# Altium schematic sheet Main.SchDoc(Main)
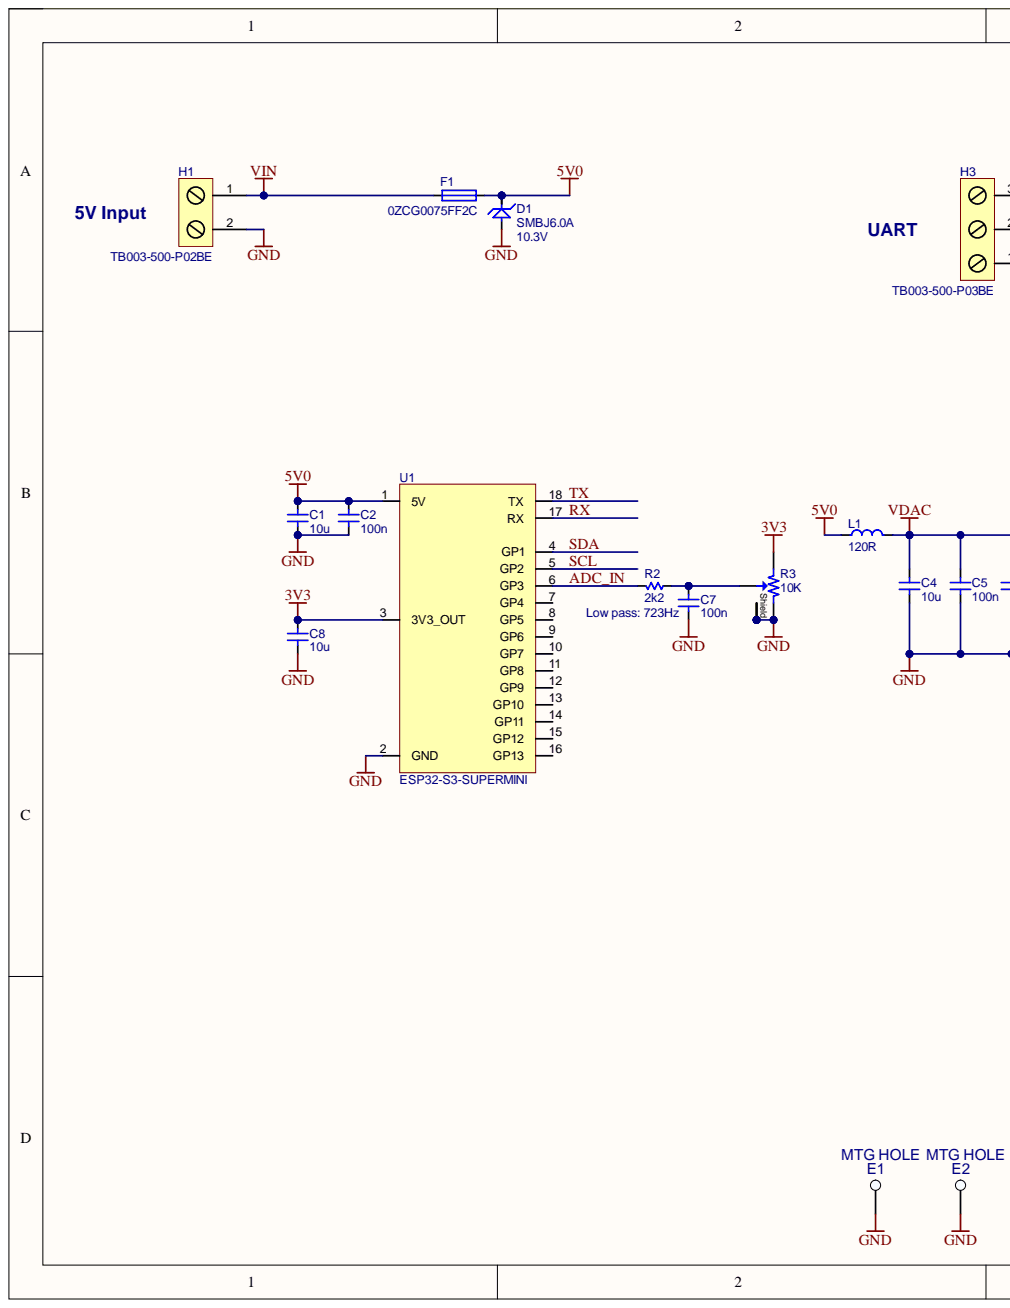
[diagram p0: Main.SchDoc, left]
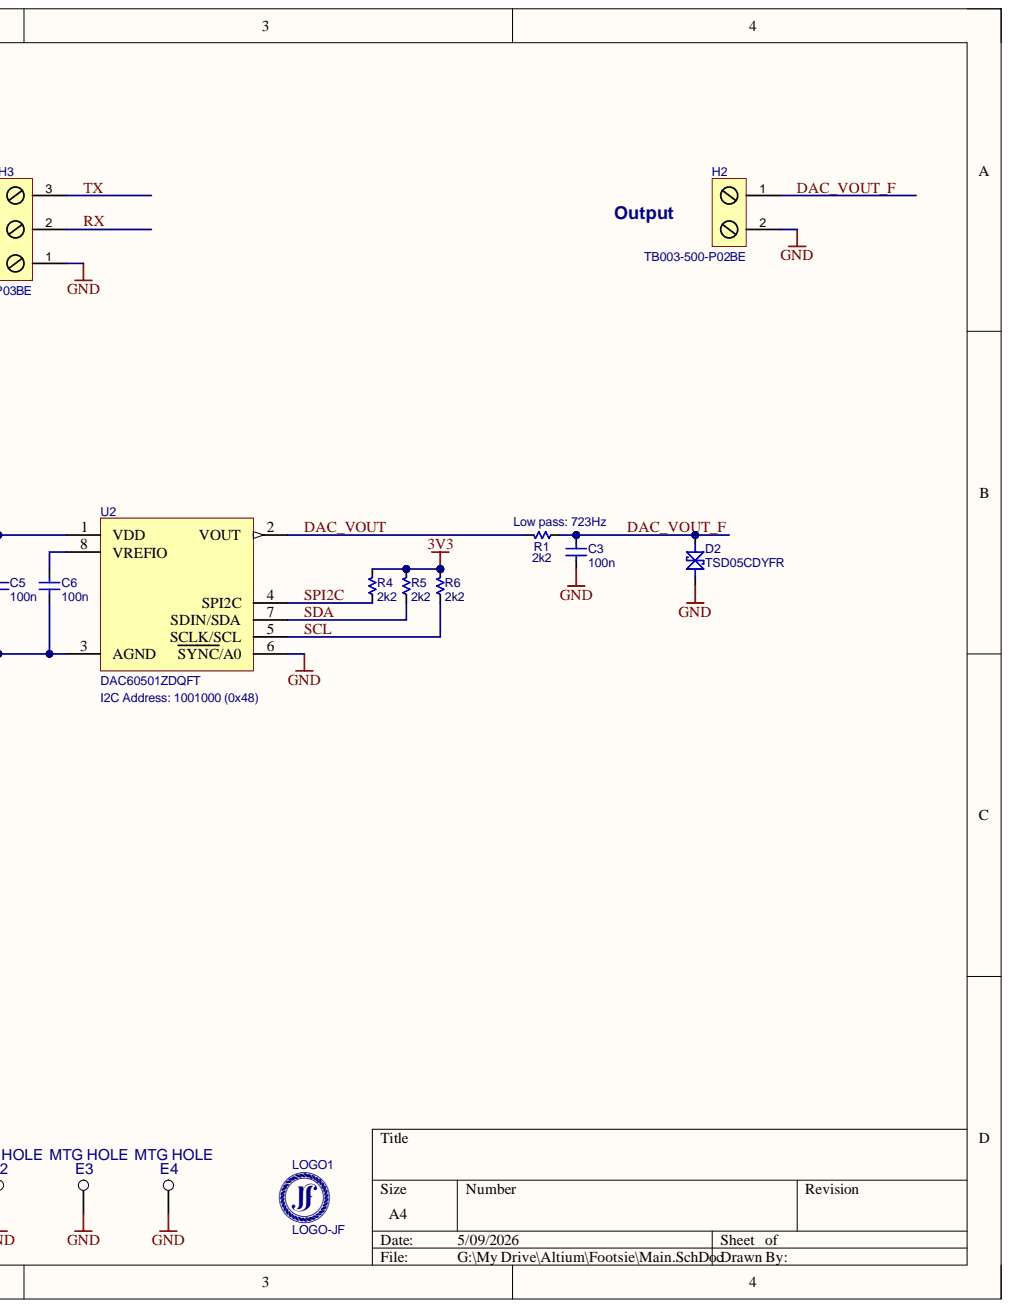
[diagram p1: Main.SchDoc, right]

## Parts
C1  10u  [0402CN_0.50]
C2  100n  [0402CN_0.50]
C3  100n  [0402CN_0.50]
C4  10u  [0402CN_0.50]
C5  100n  [0402CN_0.50]
C6  100n  [0402CN_0.50]
C7  100n  [0402CN_0.50]
C8  10u  [0402CN_0.50]
D1  SMBJ6.0A  Voltage: 10.3V  [SMB_DIO_SMBJ6.0A]
D2  TSD05CDYFR  [SOD-323-DIODE]
E1  MTG HOLE  [MTG HOLE_3.2MM]  (no BOM)
E2  MTG HOLE  [MTG HOLE_3.2MM]  (no BOM)
E3  MTG HOLE  [MTG HOLE_3.2MM]  (no BOM)
E4  MTG HOLE  [MTG HOLE_3.2MM]  (no BOM)
F1  0ZCG0075FF2C  [1812PTC_0.65]
H1  TB003-500-P02BE  [CUI_TB003-500-P02BE]
H2  TB003-500-P02BE  [CUI_TB003-500-P02BE]
H3  TB003-500-P03BE  [CUI_TB003-500-P03BE]
L1  120R  [0603FBN_0.65]
LOGO1  LOGO-JF  [LOGO-JF]  (no BOM)
R1  2k2  [0402RN_0.50]
R2  2k2  [0402RN_0.50]
R3  10K  [POT_PTA4543-2015DPB103]
R4  2k2  [0402RN_0.50]
R5  2k2  [0402RN_0.50]
R6  2k2  [0402RN_0.50]
U1  ESP32-S3-SUPERMINI  [ESP32-S3-SUPERMINI]
U2  DAC60501ZDQFT  [WSON8_DAC60501]

## Nets
3V3: C8.2 R3.1 R4.2 R5.2 R6.2 U1.3(3V3_OUT)
5V0: C1.2 C2.2 D1.1 F1.1 L1.1 U1.1(5V)
GND: C1.1 C2.1 C3.1 C4.1 C5.1 C6.1 C7.1 C8.1 D1.2 D2.2 E1.0 E2.0 E3.0 E4.0 H1.2 H2.2 H3.1 R3.3 R3.S1 R3.S2 R3.S3 R3.S4 U1.2(GND) U2.3(AGND) U2.6(SYNC/A0)
VDAC: C4.2 C5.2 L1.2 U2.1(VDD)
VIN: F1.2 H1.1
ADC_IN: R2.2 U1.6(GP3)
DAC_VOUT: R1.2 U2.2(VOUT)
DAC_VOUT_F: C3.2 D2.1 H2.1 R1.1
RX: H3.2 U1.17(RX)
SCL: R6.1 U1.5(GP2) U2.5(SCLK/SCL)
SDA: R5.1 U1.4(GP1) U2.7(SDIN/SDA)
SPI2C: R4.1 U2.4(SPI2C)
TX: H3.3 U1.18(TX)
NetC6_2: C6.2 U2.8(VREFIO)
NetC7_2: C7.2 R2.1 R3.2

Not connected: U1 7(GP4) 8(GP5) 9(GP6) 10(GP7) 11(GP8) 12(GP9) 13(GP10) 14(GP11) 15(GP12) 16(GP13)

## Library data of the parts
C1 C4 C8 = 0402_CAP: CAPACITOR, CERAMIC, 10uF, 10V, X5R, SMD 0402; Manufacturer: MURATA; Manufacturer's Part #: GRM155R61A106ME11D; Tolerance: 20%; Component Kind: Standard; Package: 0402; Supplier: Digi-Key; Supplier's Part #: 490-GRM155R61A106ME11DCT-ND; Type: X5R; Value: 10u; Voltage: 10V; Library Name: FinnLib.SchLib
C2 C3 C5-C7 = 0402_CAP: CAPACITOR, CERAMIC, 100nF, 16V, X7R, SMD 0402; Manufacturer: MURATA; Manufacturer's Part #: GRM155R71C103KA01D; Tolerance: 10%; Component Kind: Standard; Package: 0402; Supplier: Digi-Key; Supplier's Part #: 490-1313-1-ND; Type: X7R; Value: 100n; Voltage: 16V; Library Name: FinnLib.SchLib
D1 = TVS_SMBJ6.0A: TVS DIODE 6VWM 10.3VC DO214AA; Manufacturer: Bourns Inc.; Component Kind: Standard; Manufacturer's Part #: SMBJ6.0A; Package: DO214AA; Supplier: Digi-Key; Supplier's Part #: SMBJ6.0ABCT-ND; Library Name: FinnLib.SchLib
D2 = TVS_TSD05CDYFR: TVS DIODE 5.5VWM 15VC SOD-323; Manufacturer: Texas Instruments; Component Kind: Standard; Manufacturer's Part #: TSD05CDYFR; Package: 0603; Supplier: Digi-Key; Supplier's Part #: 296-TSD05CDYFRCT-ND; Library Name: FinnLib.SchLib
E1-E4 = MTG HOLE: NO COMPONENT; Notes: PART OF PCB; Library Name: FinnLib.SchLib
F1 = FUSE_0ZCG0075FF2C: PTC RESET FUSE 16V 750MA 1812; Manufacturer: Bel Fuse Inc.; Component Kind: Standard; Manufacturer's Part #: 0ZCG0075FF2C; Package: 1812; Supplier: Digi-Key; Supplier's Part #: 5923-0ZCG0075FF2CCT-ND; Library Name: FinnLib.SchLib
H1 H2 = CONN_WIRE_BOARD_2WAY_SCREW: 2 Poles, Screw Type, Horizontal, 5.0 Pitch, 26~14 AWG, Terminal Block Connector; Manufacturer: CUI Devices; Component Kind: Standard; Manufacturer's Part #: TB003-500-P02BE; Supplier: Digi-Key; Supplier's Part #: 102-6161-ND; Library Name: FinnLib.SchLib
H3 = CONN_WIRE_BOARD_3WAY_SCREW: 3 Poles, Screw Type, Horizontal, 5.0 Pitch, 26~14 AWG, Terminal Block Connector; Manufacturer: CUI Devices; Component Kind: Standard; Manufacturer's Part #: TB003-500-P03BE; Supplier: Digi-Key; Supplier's Part #: 102-6162-ND; Library Name: FinnLib.SchLib
L1 = BEAD_120R_0603: FERRITE BEAD, 120R @100Mhz, 3A, 25mOhm DC, 0603, 0.65mm (Max) Height; Manufacturer: Murata; Manufacturer's Part #: BLM18SG121TN1D; Tolerance: 25%; Component Kind: Standard; Signal Integrity: Inductor; Simulation: INDUCTOR; Supplier: Digi-Key; Supplier's Part #: 490-3996-1-ND; Value: 120R; Library Name: FinnLib.SchLib
R1 R2 R4-R6 = 0402_RES: RESISTOR, 2k2Ω, 63mW, +/-1%, SMD0402; Manufacturer: Yageo; Manufacturer's Part #: RC0201FR-072K2P; Power: 0.05W; Tolerance: 1%; Component Kind: Standard; Package: 0402; Signal Integrity: Res; Simulation: RES; Supplier: Digi-Key; Supplier's Part #: 13-RC0201FR-072K2PCT-ND; Value: 2k2; Library Name: FinnLib.SchLib
R3 = POT_PTA4543-2015DPB103: 10 kOhms 0.25W, 1/4W Through Hole Slide Potentiometer Top Adjustment Type; Manufacturer: Bourns Inc.; Component Kind: Standard; Manufacturer's Part #: PTA4543-2015DPB103; Supplier: DigiKey; Supplier's Part #: PTA4543-2015DPB103-ND; Value: 10K; Library Name: FinnLib.SchLib
U1 = MODULE_ESP32-S3-SUPERMINI: ESP32-S3 Mini Development Board, Based on ESP32-S3FH4R2 Dual-Core Processor, 240MHz Running Frequency, 2.4GHz Wi-Fi & Bluetooth 5  Based on ESP32-S3FH4R2, onboard multi-function GPIO pins  Castellated Module, Suitable For SMD Applications; Manufacturer: Waveshare; Manufacturer Part Number: WS-25081; Component Kind: Standard; Supplier: Core Electronics; Supplier Part Number: WS-25081; Library Name: FinnLib.SchLib
U2 = IC_TI_DAC60501: 12 Bit Digital to Analog Converter 1 8-WSON (2x2); Manufacturer: Texas Instruments; Component Kind: Standard; Manufacturer's Part #: DAC60501ZDQFT; Supplier: Digikey; Supplier's Part #: 296-DAC60501ZDQFTCT-ND; Library Name: FinnLib.SchLib
LOGO1 = LOGO-JF: Supplier: Digi-Key; Library Name: FinnLib.SchLib
